# Altium schematic sheet SCH-CAPE-Nucleo_Interface_V1R0.SchDoc(SCH-CAPE-Nucleo_Interface_V1R0)
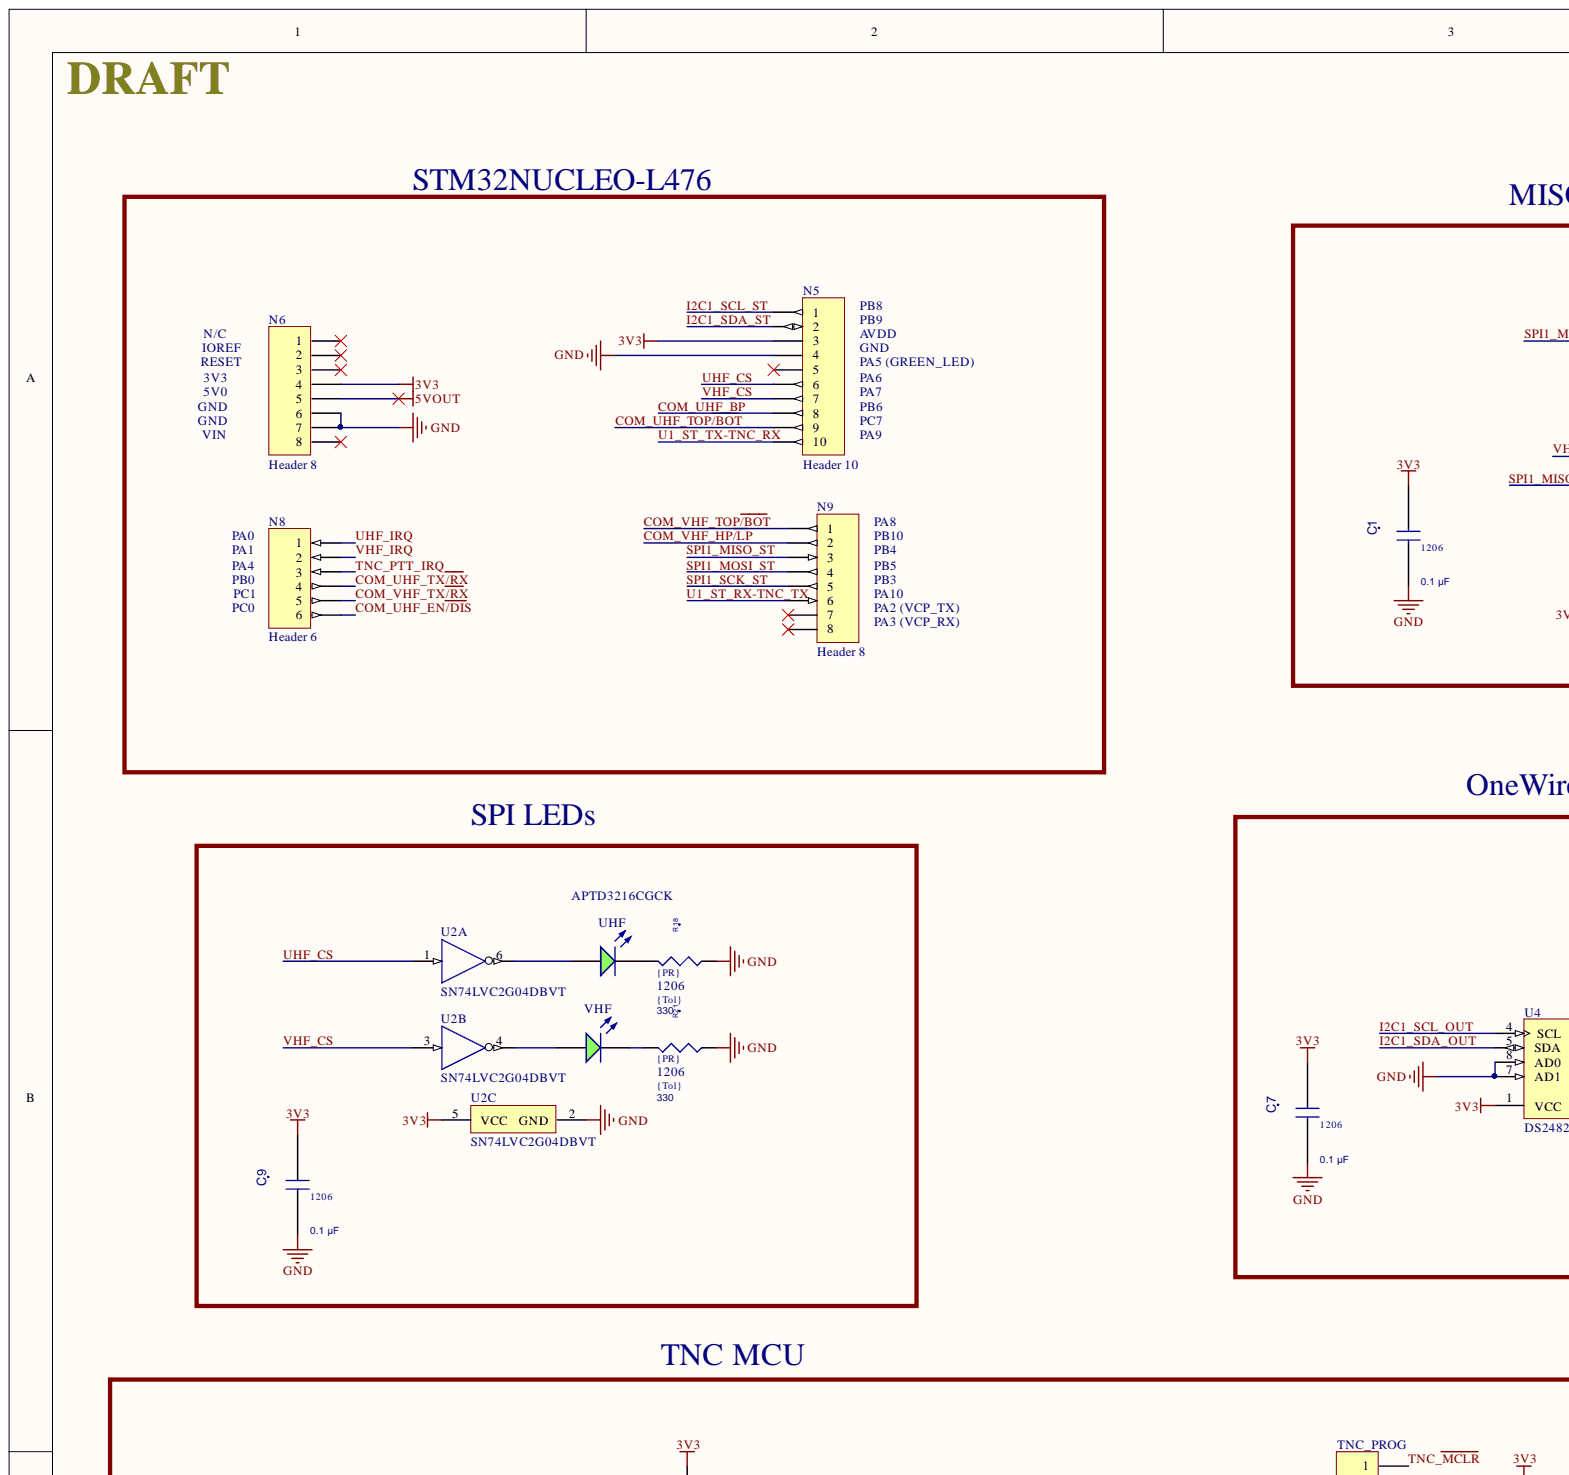
[diagram p0: SCH-CAPE-Nucleo_Interface_V1R0.SchDoc, top left]
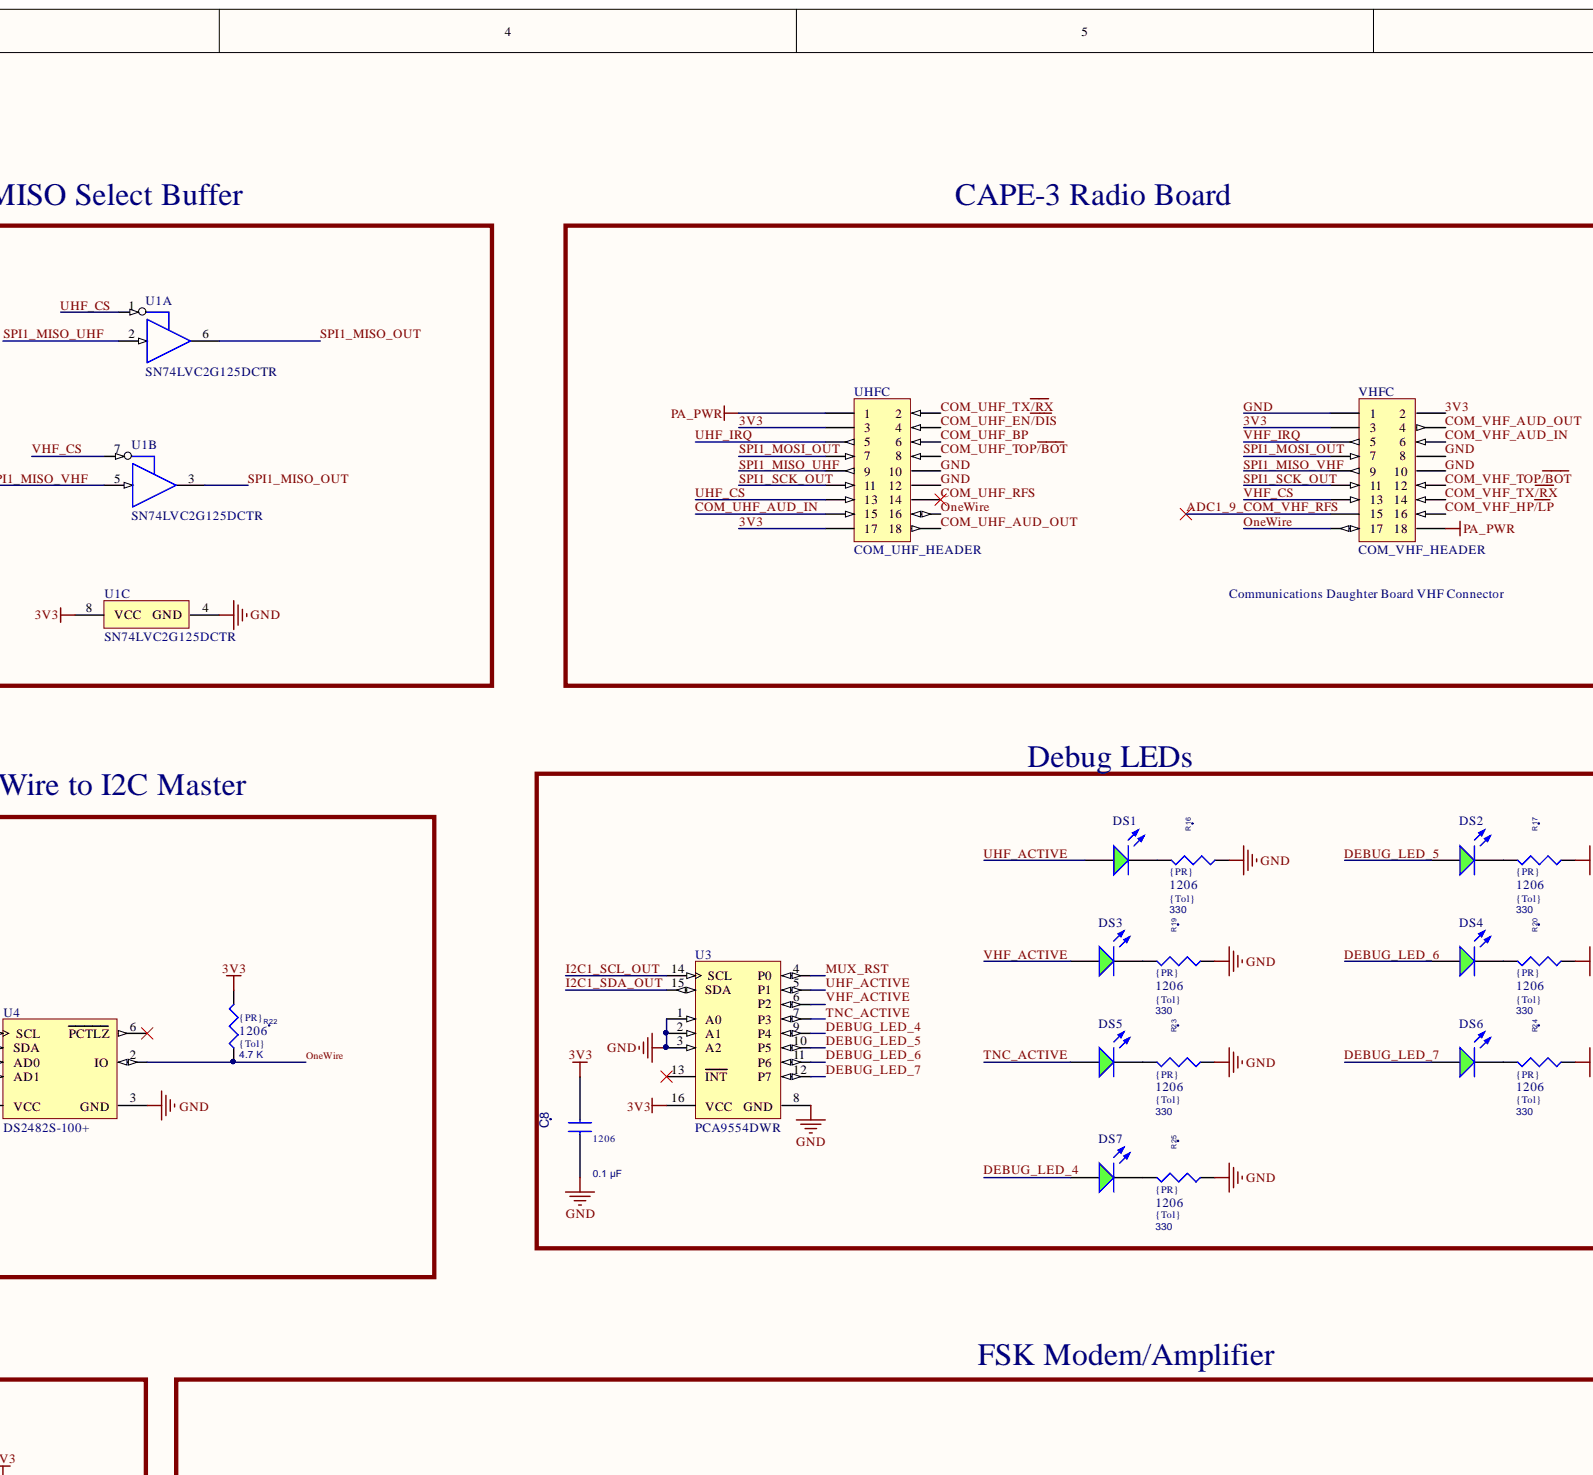
[diagram p1: SCH-CAPE-Nucleo_Interface_V1R0.SchDoc, top centre]
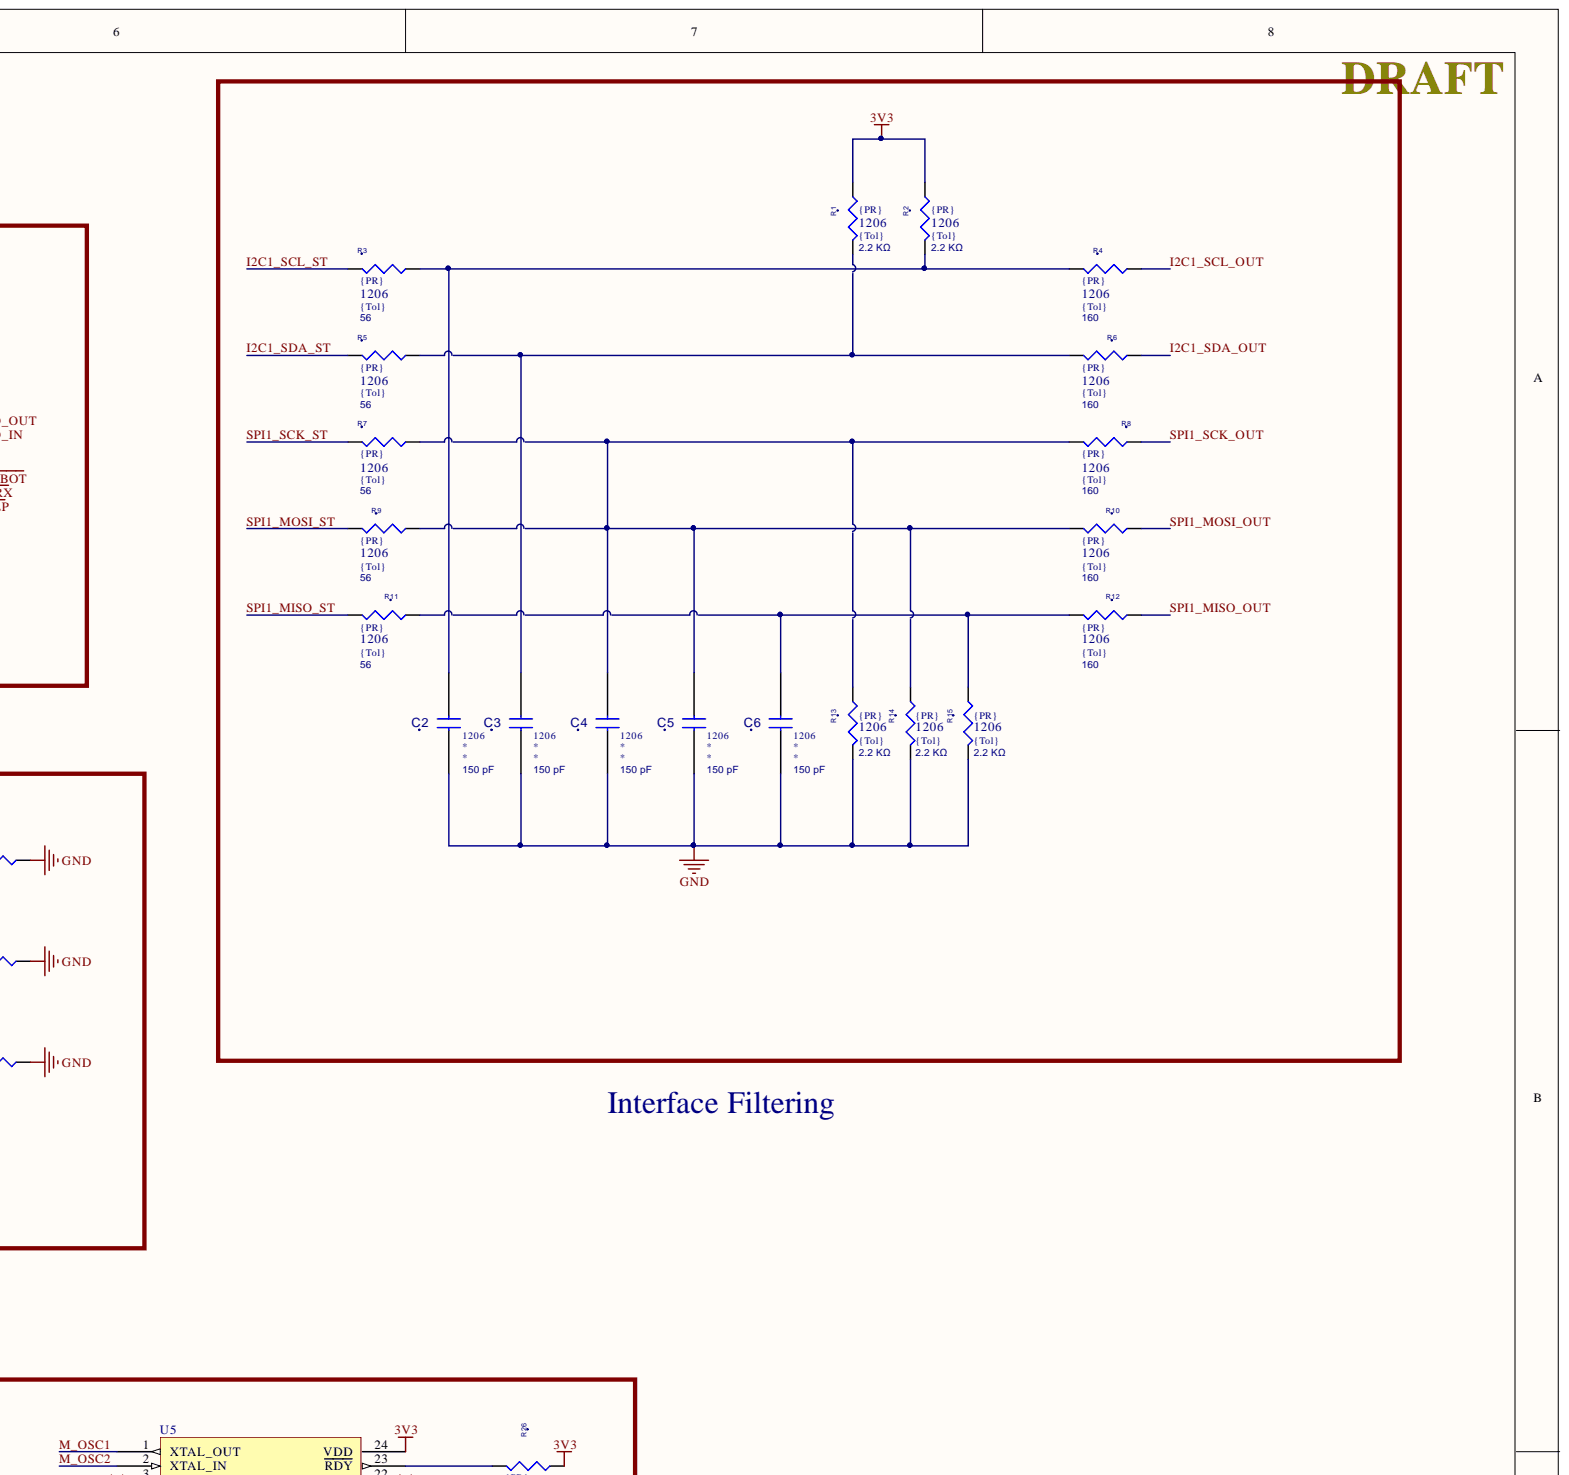
[diagram p2: SCH-CAPE-Nucleo_Interface_V1R0.SchDoc, top right]
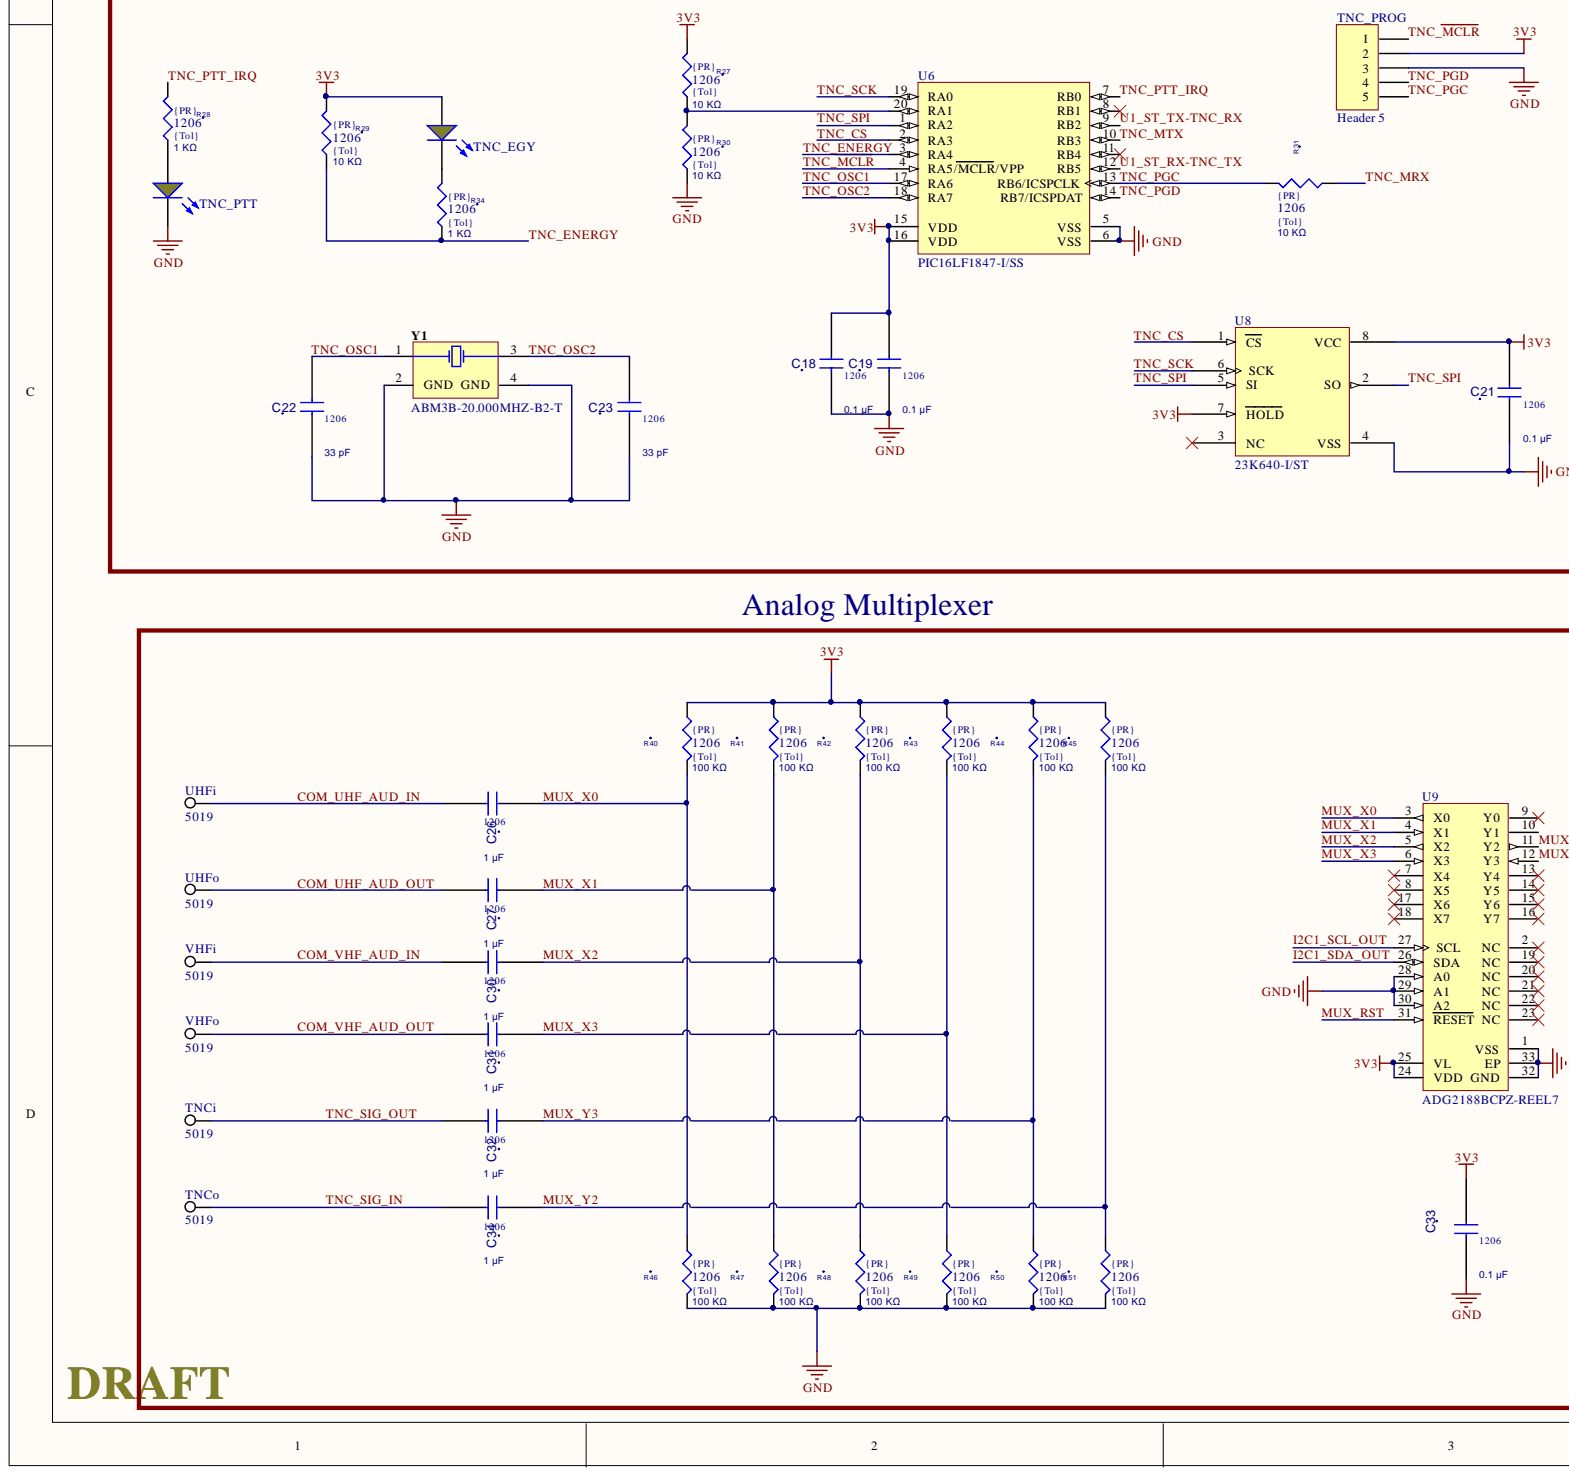
[diagram p3: SCH-CAPE-Nucleo_Interface_V1R0.SchDoc, bottom left]
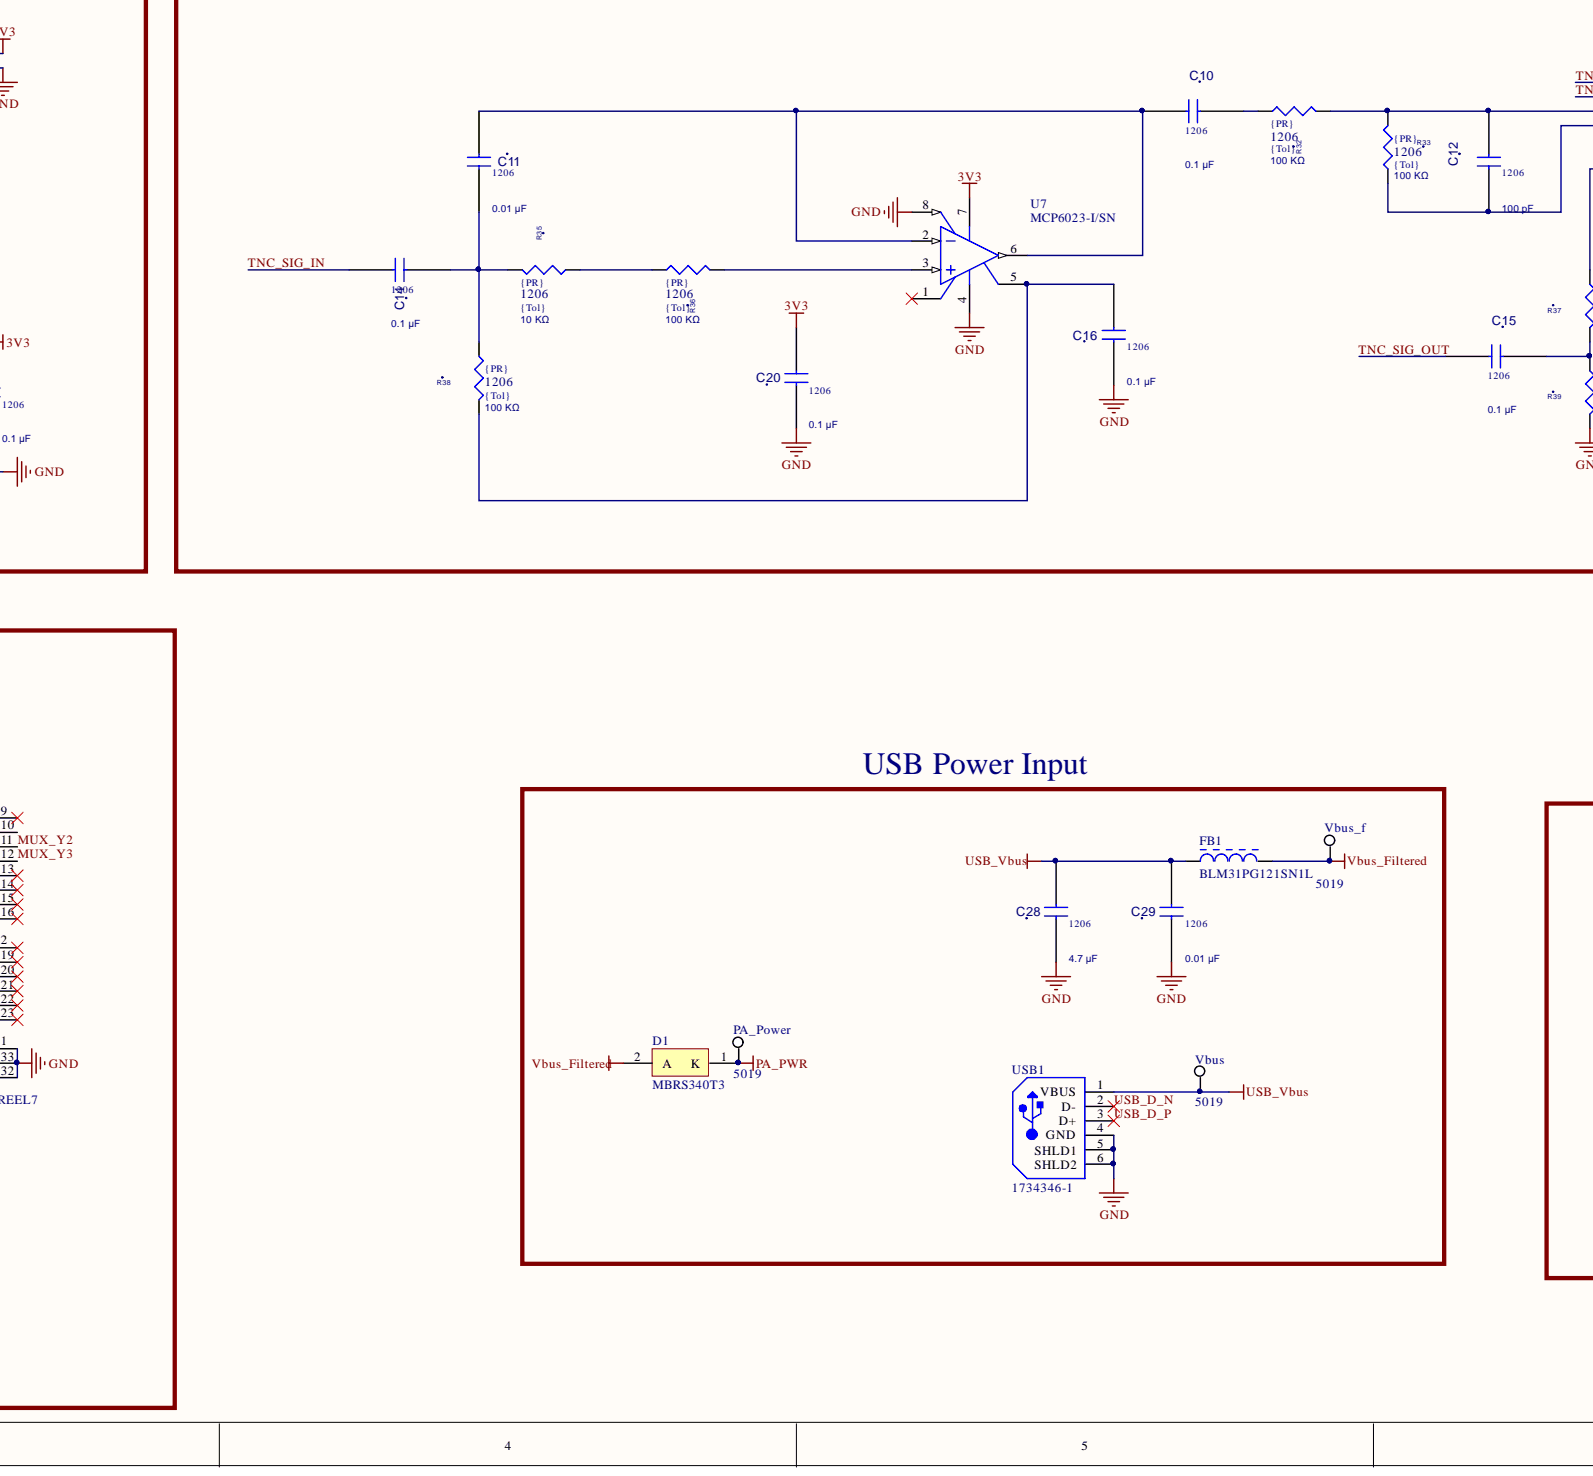
[diagram p4: SCH-CAPE-Nucleo_Interface_V1R0.SchDoc, bottom centre]
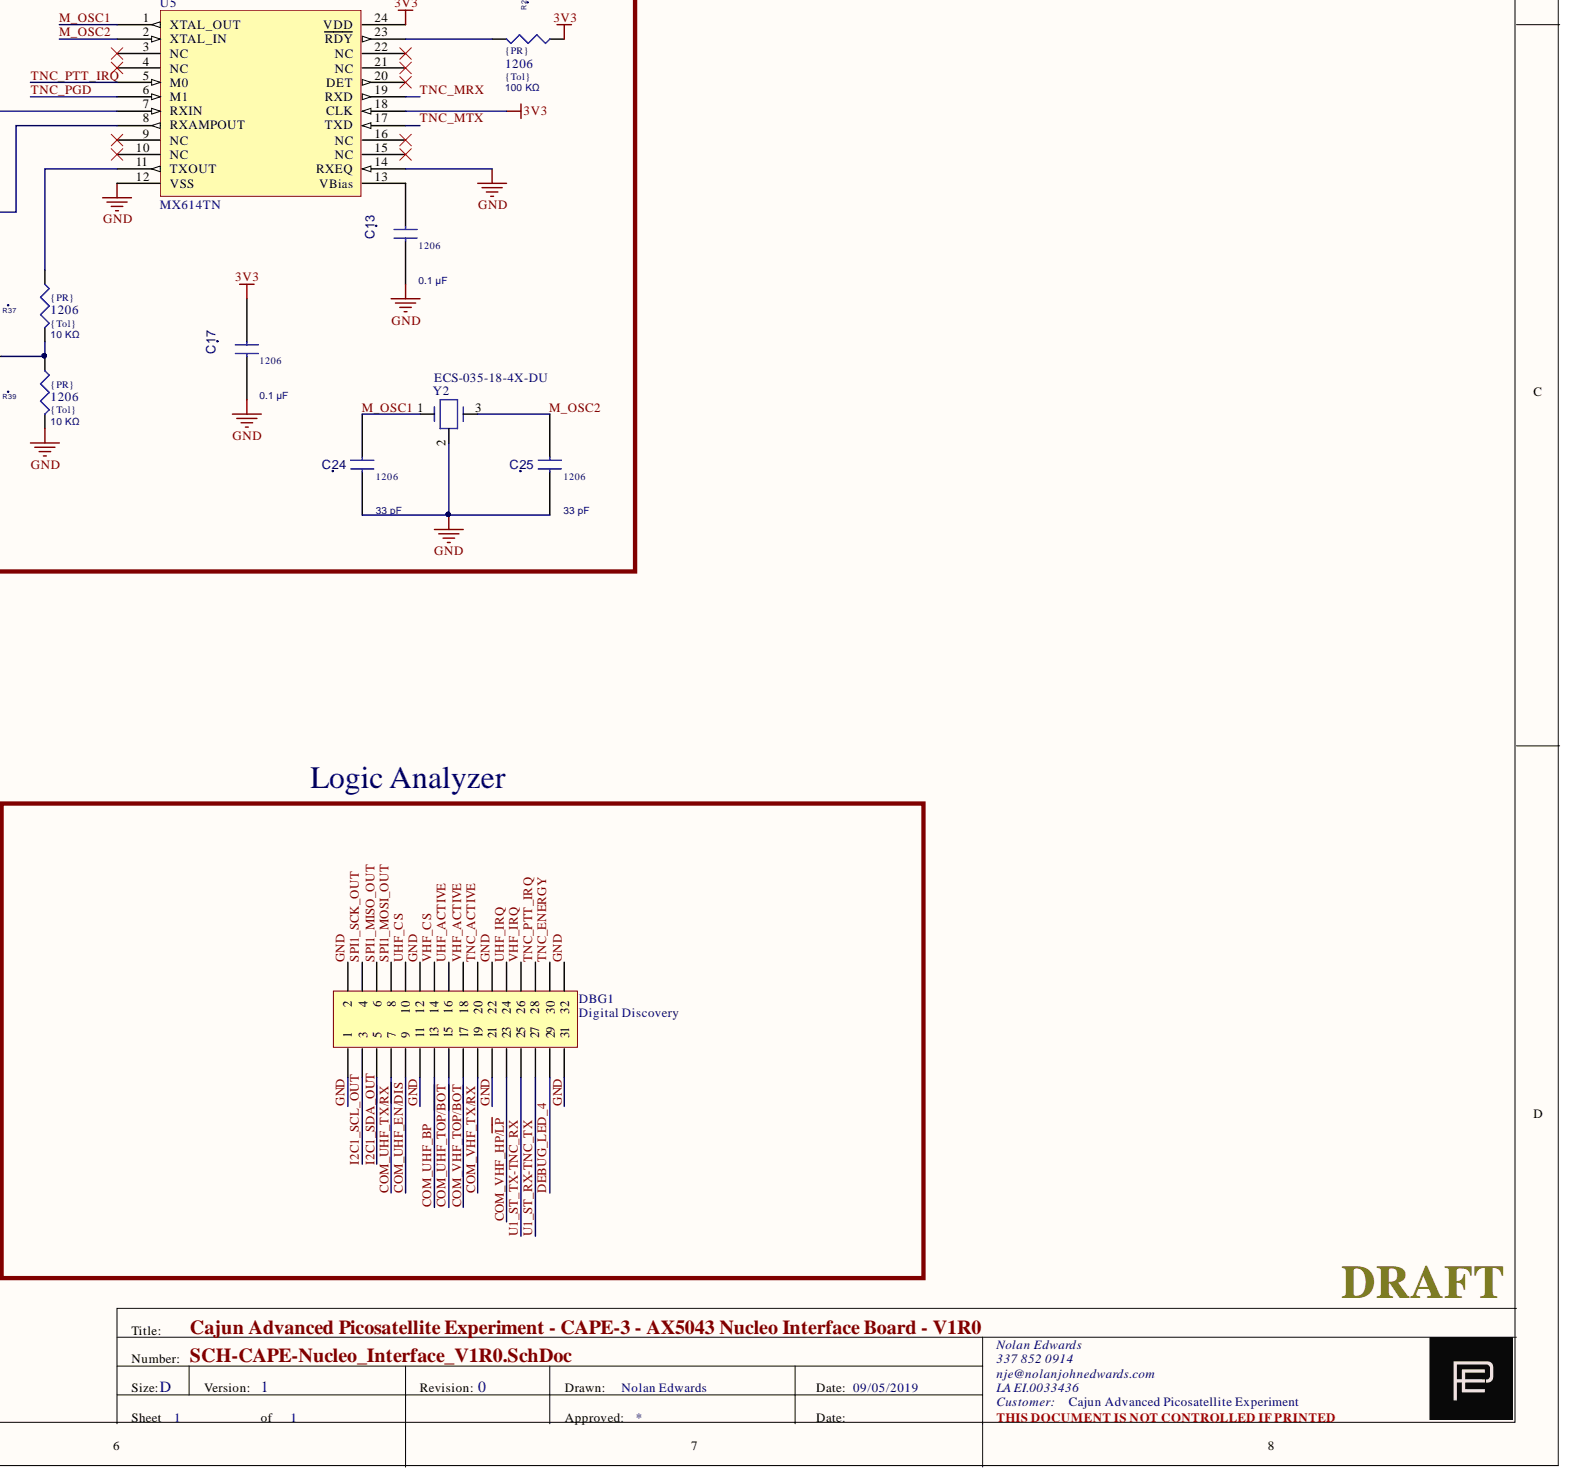
[diagram p5: SCH-CAPE-Nucleo_Interface_V1R0.SchDoc, bottom right]

## Parts
C1  value 0.1 µF  Package: 1206  [0805_GENERIC]  (hidden comment: SMT Capacitor)
C2  value 150 pF  Package: 1206  [1206_GENERIC]  (hidden comment: SMT Capacitor)
C3  value 150 pF  Package: 1206  [1206_GENERIC]  (hidden comment: SMT Capacitor)
C4  value 150 pF  Package: 1206  [1206_GENERIC]  (hidden comment: SMT Capacitor)
C5  value 150 pF  Package: 1206  [1206_GENERIC]  (hidden comment: SMT Capacitor)
C6  value 150 pF  Package: 1206  [1206_GENERIC]  (hidden comment: SMT Capacitor)
C7  value 0.1 µF  Package: 1206  [0805_GENERIC]  (hidden comment: SMT Capacitor)
C8  value 0.1 µF  Package: 1206  [0805_GENERIC]  (hidden comment: SMT Capacitor)
C9  value 0.1 µF  Package: 1206  [0805_GENERIC]  (hidden comment: SMT Capacitor)
C10  value 0.1 µF  Package: 1206  [0805_GENERIC]  (hidden comment: SMT Capacitor)
C11  value 0.01 µF  Package: 1206  [1206_GENERIC]  (hidden comment: SMT Capacitor)
C12  value 100 pF  Package: 1206  [0805_GENERIC]  (hidden comment: SMT Capacitor)
C13  value 0.1 µF  Package: 1206  [0805_GENERIC]  (hidden comment: SMT Capacitor)
C14  value 0.1 µF  Package: 1206  [0805_GENERIC]  (hidden comment: SMT Capacitor)
C15  value 0.1 µF  Package: 1206  [0805_GENERIC]  (hidden comment: SMT Capacitor)
C16  value 0.1 µF  Package: 1206  [0805_GENERIC]  (hidden comment: SMT Capacitor)
C17  value 0.1 µF  Package: 1206  [0805_GENERIC]  (hidden comment: SMT Capacitor)
C18  value 0.1 µF  Package: 1206  [0805_GENERIC]  (hidden comment: SMT Capacitor)
C19  value 0.1 µF  Package: 1206  [0805_GENERIC]  (hidden comment: SMT Capacitor)
C20  value 0.1 µF  Package: 1206  [0805_GENERIC]  (hidden comment: SMT Capacitor)
C21  value 0.1 µF  Package: 1206  [0805_GENERIC]  (hidden comment: SMT Capacitor)
C22  value 33 pF  Package: 1206  [0805_GENERIC]  (hidden comment: SMT Capacitor)
C23  value 33 pF  Package: 1206  [0805_GENERIC]  (hidden comment: SMT Capacitor)
C24  value 33 pF  Package: 1206  [0805_GENERIC]  (hidden comment: SMT Capacitor)
C25  value 33 pF  Package: 1206  [0805_GENERIC]  (hidden comment: SMT Capacitor)
C26  value 1 µF  Package: 1206  [0805_GENERIC]  (hidden comment: SMT Capacitor)
C27  value 1 µF  Package: 1206  [0805_GENERIC]  (hidden comment: SMT Capacitor)
C28  value 4.7 µF  Package: 1206  [1206_GENERIC]  (hidden comment: SMT Capacitor)
C29  value 0.01 µF  Package: 1206  [1206_GENERIC]  (hidden comment: SMT Capacitor)
C30  value 1 µF  Package: 1206  [0805_GENERIC]  (hidden comment: SMT Capacitor)
C31  value 1 µF  Package: 1206  [0805_GENERIC]  (hidden comment: SMT Capacitor)
C32  value 1 µF  Package: 1206  [0805_GENERIC]  (hidden comment: SMT Capacitor)
C33  value 0.1 µF  Package: 1206  [0805_GENERIC]  (hidden comment: SMT Capacitor)
C34  value 1 µF  Package: 1206  [0805_GENERIC]  (hidden comment: SMT Capacitor)
D1  MBRS340T3  [ONSC-SMC-2-403-03_V]
DBG1  Digital Discovery  [HDR2X16H]
DS1  APTD3216CGCK  [KING-APTD3216CGCK_V]
DS2  APTD3216CGCK  [KING-APTD3216CGCK_V]
DS3  APTD3216CGCK  [KING-APTD3216CGCK_V]
DS4  APTD3216CGCK  [KING-APTD3216CGCK_V]
DS5  APTD3216CGCK  [KING-APTD3216CGCK_V]
DS6  APTD3216CGCK  [KING-APTD3216CGCK_V]
DS7  APTD3216CGCK  [KING-APTD3216CGCK_V]
FB1  BLM31PG121SN1L  [MURA-BLM31-B35-CHIP-2_V2]
N5  Header 10  [HDR1X10]
N6  Header 8  [HDR1X8]
N8  Header 6  [HDR1X6]
N9  Header 8  [HDR1X8]
PA_Power  5019  [KSTN-5019_V]
R1  value 2.2 KΩ  Tolerance: {Tol}  Power Rating: {PR}  Package: 1206  [1206_GENERIC]  (hidden comment: SMT Resistor)
R2  value 2.2 KΩ  Tolerance: {Tol}  Power Rating: {PR}  Package: 1206  [1206_GENERIC]  (hidden comment: SMT Resistor)
R3  Tolerance: {Tol}  Power Rating: {PR}  Package: 1206  [1206_GENERIC]  (hidden comment: SMT Resistor)
R4  Tolerance: {Tol}  Power Rating: {PR}  Package: 1206  [1206_GENERIC]  (hidden comment: SMT Resistor)
R5  value 56  Tolerance: {Tol}  Power Rating: {PR}  Package: 1206  [1206_GENERIC]  (hidden comment: SMT Resistor)
R6  value 160  Tolerance: {Tol}  Power Rating: {PR}  Package: 1206  [1206_GENERIC]  (hidden comment: SMT Resistor)
R7  value 56  Tolerance: {Tol}  Power Rating: {PR}  Package: 1206  [1206_GENERIC]  (hidden comment: SMT Resistor)
R8  value 160  Tolerance: {Tol}  Power Rating: {PR}  Package: 1206  [1206_GENERIC]  (hidden comment: SMT Resistor)
R9  value 56  Tolerance: {Tol}  Power Rating: {PR}  Package: 1206  [1206_GENERIC]  (hidden comment: SMT Resistor)
R10  value 160  Tolerance: {Tol}  Power Rating: {PR}  Package: 1206  [1206_GENERIC]  (hidden comment: SMT Resistor)
R11  value 56  Tolerance: {Tol}  Power Rating: {PR}  Package: 1206  [1206_GENERIC]  (hidden comment: SMT Resistor)
R12  value 160  Tolerance: {Tol}  Power Rating: {PR}  Package: 1206  [1206_GENERIC]  (hidden comment: SMT Resistor)
R13  value 2.2 KΩ  Tolerance: {Tol}  Power Rating: {PR}  Package: 1206  [1206_GENERIC]  (hidden comment: SMT Resistor)
R14  value 2.2 KΩ  Tolerance: {Tol}  Power Rating: {PR}  Package: 1206  [1206_GENERIC]  (hidden comment: SMT Resistor)
R15  value 2.2 KΩ  Tolerance: {Tol}  Power Rating: {PR}  Package: 1206  [1206_GENERIC]  (hidden comment: SMT Resistor)
R16  Tolerance: {Tol}  Power Rating: {PR}  Package: 1206  [1206_GENERIC]  (hidden comment: SMT Resistor)
R17  Tolerance: {Tol}  Power Rating: {PR}  Package: 1206  [1206_GENERIC]  (hidden comment: SMT Resistor)
R18  Power Rating: {PR}  Package: 1206  [1206_GENERIC]  (hidden comment: SMT Resistor)
R19  value 330  Tolerance: {Tol}  Power Rating: {PR}  Package: 1206  [1206_GENERIC]  (hidden comment: SMT Resistor)
R20  value 330  Tolerance: {Tol}  Power Rating: {PR}  Package: 1206  [1206_GENERIC]  (hidden comment: SMT Resistor)
R21  value 330  Tolerance: {Tol}  Power Rating: {PR}  Package: 1206  [1206_GENERIC]  (hidden comment: SMT Resistor)
R22  value 4.7 K  Tolerance: {Tol}  Power Rating: {PR}  Package: 1206  [1206_GENERIC]  (hidden comment: SMT Resistor)
R23  value 330  Tolerance: {Tol}  Power Rating: {PR}  Package: 1206  [1206_GENERIC]  (hidden comment: SMT Resistor)
R24  value 330  Tolerance: {Tol}  Power Rating: {PR}  Package: 1206  [1206_GENERIC]  (hidden comment: SMT Resistor)
R25  value 330  Tolerance: {Tol}  Power Rating: {PR}  Package: 1206  [1206_GENERIC]  (hidden comment: SMT Resistor)
R26  value 100 KΩ  Tolerance: {Tol}  Power Rating: {PR}  Package: 1206  [1206_GENERIC]  (hidden comment: SMT Resistor)
R27  value 10 KΩ  Tolerance: {Tol}  Power Rating: {PR}  Package: 1206  [1206_GENERIC]  (hidden comment: SMT Resistor)
R28  value 1 KΩ  Tolerance: {Tol}  Power Rating: {PR}  Package: 1206  [1206_GENERIC]  (hidden comment: SMT Resistor)
R29  value 10 KΩ  Tolerance: {Tol}  Power Rating: {PR}  Package: 1206  [1206_GENERIC]  (hidden comment: SMT Resistor)
R30  value 10 KΩ  Tolerance: {Tol}  Power Rating: {PR}  Package: 1206  [1206_GENERIC]  (hidden comment: SMT Resistor)
R31  value 10 KΩ  Tolerance: {Tol}  Power Rating: {PR}  Package: 1206  [1206_GENERIC]  (hidden comment: SMT Resistor)
R32  value 100 KΩ  Tolerance: {Tol}  Power Rating: {PR}  Package: 1206  [1206_GENERIC]  (hidden comment: SMT Resistor)
R33  value 100 KΩ  Tolerance: {Tol}  Power Rating: {PR}  Package: 1206  [1206_GENERIC]  (hidden comment: SMT Resistor)
R34  value 1 KΩ  Tolerance: {Tol}  Power Rating: {PR}  Package: 1206  [1206_GENERIC]  (hidden comment: SMT Resistor)
R35  value 10 KΩ  Tolerance: {Tol}  Power Rating: {PR}  Package: 1206  [1206_GENERIC]  (hidden comment: SMT Resistor)
R36  value 100 KΩ  Tolerance: {Tol}  Power Rating: {PR}  Package: 1206  [1206_GENERIC]  (hidden comment: SMT Resistor)
R37  value 10 KΩ  Tolerance: {Tol}  Power Rating: {PR}  Package: 1206  [1206_GENERIC]  (hidden comment: SMT Resistor)
R38  value 100 KΩ  Tolerance: {Tol}  Power Rating: {PR}  Package: 1206  [1206_GENERIC]  (hidden comment: SMT Resistor)
R39  value 10 KΩ  Tolerance: {Tol}  Power Rating: {PR}  Package: 1206  [1206_GENERIC]  (hidden comment: SMT Resistor)
R40  value 100 KΩ  Tolerance: {Tol}  Power Rating: {PR}  Package: 1206  [1206_GENERIC]  (hidden comment: SMT Resistor)
R41  value 100 KΩ  Tolerance: {Tol}  Power Rating: {PR}  Package: 1206  [1206_GENERIC]  (hidden comment: SMT Resistor)
R42  value 100 KΩ  Tolerance: {Tol}  Power Rating: {PR}  Package: 1206  [1206_GENERIC]  (hidden comment: SMT Resistor)
R43  value 100 KΩ  Tolerance: {Tol}  Power Rating: {PR}  Package: 1206  [1206_GENERIC]  (hidden comment: SMT Resistor)
R44  value 100 KΩ  Tolerance: {Tol}  Power Rating: {PR}  Package: 1206  [1206_GENERIC]  (hidden comment: SMT Resistor)
R45  value 100 KΩ  Tolerance: {Tol}  Power Rating: {PR}  Package: 1206  [1206_GENERIC]  (hidden comment: SMT Resistor)
R46  value 100 KΩ  Tolerance: {Tol}  Power Rating: {PR}  Package: 1206  [1206_GENERIC]  (hidden comment: SMT Resistor)
R47  value 100 KΩ  Tolerance: {Tol}  Power Rating: {PR}  Package: 1206  [1206_GENERIC]  (hidden comment: SMT Resistor)
R48  value 100 KΩ  Tolerance: {Tol}  Power Rating: {PR}  Package: 1206  [1206_GENERIC]  (hidden comment: SMT Resistor)
R49  value 100 KΩ  Tolerance: {Tol}  Power Rating: {PR}  Package: 1206  [1206_GENERIC]  (hidden comment: SMT Resistor)
R50  value 100 KΩ  Tolerance: {Tol}  Power Rating: {PR}  Package: 1206  [1206_GENERIC]  (hidden comment: SMT Resistor)
R51  value 100 KΩ  Tolerance: {Tol}  Power Rating: {PR}  Package: 1206  [1206_GENERIC]  (hidden comment: SMT Resistor)
TNC_EGY  APTD3216CGCK  [KING-APTD3216CGCK_V]
TNC_PROG  Header 5  [HDR1X5]
TNC_PTT  APTD3216CGCK  [KING-APTD3216CGCK_V]
TNCi  5019  [KSTN-5019_V]
TNCo  5019  [KSTN-5019_V]
U1  SN74LVC2G125DCTR  [DCT0008A_M]  (parts A,B,C)
U2  SN74LVC2G04DBVT  [DBV0006A_M]  (parts A,B,C)
U3  PCA9554DWR  [DW0016A_M]
U4  DS2482S-100+  [MAXM-SO-8_V]
U5  MX614TN  [24SSOP209_M]
U6  PIC16LF1847-I/SS  [MCHP-SSOP-SS20_M]
U7  MCP6023-I/SN  [SOIC-SN8_L]
U8  23K640-I/ST  [TSSOP-ST8_M]
U9  ADG2188BCPZ-REEL7  [ADI-CP-32-2_M]
UHF  APTD3216CGCK  [KING-APTD3216CGCK_V]
UHFC  COM_UHF_HEADER  [Molex 87758-1816]
UHFi  5019  [KSTN-5019_V]
UHFo  5019  [KSTN-5019_V]
USB1  1734346-1  [TECO-1734346-1_V]
Vbus  5019  [KSTN-5019_V]
Vbus_f  5019  [KSTN-5019_V]
VHF  APTD3216CGCK  [KING-APTD3216CGCK_V]
VHFC  COM_VHF_HEADER  [Molex 87758-1816]
VHFi  5019  [KSTN-5019_V]
VHFo  5019  [KSTN-5019_V]
Y1  ABM3B-20.000MHZ-B2-T  [ABRA-ABM3B_V]
Y2  ECS-035-18-4X-DU  [ECS-4X-3IL]

## Nets
5VOUT: N6.5
PA_PWR: D1.1 PA_Power.1 UHFC.1 VHFC.18
USB_Vbus: C28.2 C29.2 FB1.1 USB1.1(VBUS) Vbus.1
Vbus_Filtered: D1.2 FB1.2 Vbus_f.1
3V3: C1.1 C7.1 C8.1 C9.1 C17.1 C18.2 C19.2 C20.2 C21.2 C33.1 N5.3 N6.4 R1.2 R2.2 R22.1 R26.2 R27.1 R29.1 R40.2 R41.2 R42.2 R43.2 R44.2 R45.2 TNC_EGY.1 TNC_PROG.2 U1.8(VCC) U2.5(VCC) U3.16(VCC) U4.1(VCC) U5.18(CLK) U5.24(VDD) U6.15(VDD) U6.16(VDD) U7.7 U8.7(HOLD) U8.8(VCC) U9.24(VDD) U9.25(VL) UHFC.3 UHFC.17 VHFC.2 VHFC.3
ADC1_9_COM_VHF_RFS: VHFC.15
COM_UHF_AUD_IN: C26.2 UHFC.15 UHFi.1
COM_UHF_AUD_OUT: C27.2 UHFC.18 UHFo.1
COM_UHF_BP: DBG1.13 N5.8 UHFC.6
COM_UHF_EN/~{DIS}: DBG1.9 N8.6 UHFC.4
COM_UHF_RFS: UHFC.14
COM_UHF_TOP/~{BOT}: DBG1.15 N5.9 UHFC.8
COM_UHF_TX/~{RX}: DBG1.7 N8.4 UHFC.2
COM_VHF_AUD_IN: C30.2 VHFC.6 VHFi.1
COM_VHF_AUD_OUT: C31.2 VHFC.4 VHFo.1
COM_VHF_HP/~{LP}: DBG1.23 N9.2 VHFC.16
COM_VHF_TOP/~{BOT}: DBG1.17 N9.1 VHFC.12
COM_VHF_TX/~{RX}: DBG1.19 N8.5 VHFC.14
DEBUG_LED_4: DBG1.29 DS7.1 U3.9(P4)
DEBUG_LED_5: DS2.1 U3.10(P5)
DEBUG_LED_6: DS4.1 U3.11(P6)
DEBUG_LED_7: DS6.1 U3.12(P7)
GND: C1.2 C2.1 C3.1 C4.1 C5.1 C6.1 C7.2 C8.2 C9.2 C13.2 C16.1 C17.2 C18.1 C19.1 C20.1 C21.1 C22.1 C23.1 C24.1 C25.1 C28.1 C29.1 C33.2 DBG1.1 DBG1.2 DBG1.11 DBG1.12 DBG1.21 DBG1.22 DBG1.31 DBG1.32 N5.4 N6.6 N6.7 R13.1 R14.1 R15.1 R16.2 R17.2 R18.2 R19.2 R20.2 R21.2 R23.2 R24.2 R25.2 R30.2 R39.1 R46.1 R47.1 R48.1 R49.1 R50.1 R51.1 TNC_PROG.3 TNC_PTT.2 U1.4(GND) U2.2(GND) U3.1(A0) U3.2(A1) U3.3(A2) U3.8(GND) U4.3(GND) U4.7(AD1) U4.8(AD0) U5.12(VSS) U5.14(RXEQ) U6.5(VSS) U6.6(VSS) U7.4 U7.8 U8.4(VSS) U9.1(VSS) U9.28(A0) U9.29(A1) U9.30(A2) U9.32(GND) U9.33(EP) UHFC.10 UHFC.12 USB1.4(GND) USB1.5(SHLD1) USB1.6(SHLD2) VHFC.1 VHFC.8 VHFC.10 Y1.2(GND) Y1.4(GND) Y2.2
I2C1_SCL_OUT: DBG1.3 R4.2 U3.14(SCL) U4.4(SCL) U9.27(SCL)
I2C1_SCL_ST: N5.1 R3.1
I2C1_SDA_OUT: DBG1.5 R6.2 U3.15(SDA) U4.5(SDA) U9.26(SDA)
I2C1_SDA_ST: N5.2 R5.1
M_OSC1: C24.2 U5.1(XTAL_OUT) Y2.1
M_OSC2: C25.2 U5.2(XTAL_IN) Y2.3
MUX_RST: U3.4(P0) U9.31(RESET)
MUX_X0: C26.1 R40.1 R46.2 U9.3(X0)
MUX_X1: C27.1 R41.1 R47.2 U9.4(X1)
MUX_X2: C30.1 R42.1 R48.2 U9.5(X2)
MUX_X3: C31.1 R43.1 R49.2 U9.6(X3)
MUX_Y2: C34.1 R45.1 R51.2 U9.11(Y2)
MUX_Y3: C32.1 R44.1 R50.2 U9.12(Y3)
OneWire: R22.2 U4.2(IO) UHFC.16 VHFC.17
SPI1_MISO_OUT: DBG1.6 R12.2 U1.3 U1.6
SPI1_MISO_ST: N9.3 R11.1
SPI1_MISO_UHF: U1.2 UHFC.9
SPI1_MISO_VHF: U1.5 VHFC.9
SPI1_MOSI_OUT: DBG1.8 R10.2 UHFC.7 VHFC.7
SPI1_MOSI_ST: N9.4 R9.1
SPI1_SCK_OUT: DBG1.4 R8.2 UHFC.11 VHFC.11
SPI1_SCK_ST: N9.5 R7.1
TNC_ACTIVE: DBG1.20 DS5.1 U3.7(P3)
TNC_CS: U6.2(RA3) U8.1(CS)
TNC_ENERGY: DBG1.30 R29.2 R34.2 U6.3(RA4)
TNC_~{MCLR}: TNC_PROG.1 U6.4(RA5/MCLR/VPP)
TNC_MRX: R31.2 U5.19(RXD)
TNC_MTX: U5.17(TXD) U6.10(RB3)
TNC_OSC1: C22.2 U6.17(RA6) Y1.1
TNC_OSC2: C23.2 U6.18(RA7) Y1.3
TNC_PGC: R31.1 TNC_PROG.5 U6.13(RB6/ICSPCLK)
TNC_PGD: TNC_PROG.4 U5.6(M1) U6.14(RB7/ICSPDAT)
TNC_PTT_IRQ: DBG1.28 N8.3 R28.1 U5.5(M0) U6.7(RB0)
TNC_SCK: U6.19(RA0) U8.6(SCK)
TNC_SIG_IN: C14.2 C34.2 TNCo.1
TNC_SIG_OUT: C15.2 C32.2 TNCi.1
TNC_SPI: U6.1(RA2) U8.2(SO) U8.5(SI)
U1_ST_RX-TNC_TX: DBG1.27 N9.6 U6.12(RB5)
U1_ST_TX-TNC_RX: DBG1.25 N5.10 U6.9(RB2)
UHF_ACTIVE: DBG1.16 DS1.1 U3.5(P1)
UHF_CS: DBG1.10 N5.6 U1.1 U2.1 UHFC.13
UHF_IRQ: DBG1.24 N8.1 UHFC.5
USB_D_N: USB1.2(D-)
USB_D_P: USB1.3(D+)
VHF_ACTIVE: DBG1.18 DS3.1 U3.6(P2)
VHF_CS: DBG1.14 N5.7 U1.7 U2.3 VHFC.13
VHF_IRQ: DBG1.26 N8.2 VHFC.5
NetC2_2: C2.2 R2.1 R3.2 R4.1
NetC3_2: C3.2 R1.1 R5.2 R6.1
NetC4_2: C4.2 C5.2 R7.2 R8.1 R9.2 R10.1 R13.2 R14.2
NetC6_2: C6.2 R11.2 R12.1 R15.2
NetC10_1: C10.1 R32.2
NetC10_2: C10.2 C11.1 U7.2 U7.6
NetC11_2: C11.2 C14.1 R35.1 R38.2
NetC12_1: C12.1 R32.1 R33.1 U5.7(RXIN)
NetC12_2: C12.2 R33.2 U5.8(RXAMPOUT)
NetC13_1: C13.1 U5.13(VBias)
NetC15_1: C15.1 R37.1 R39.2
NetC16_2: C16.2 R38.1 U7.5
NetDS1_2: DS1.2 R16.1
NetDS2_2: DS2.2 R17.1
NetDS3_2: DS3.2 R19.1
NetDS4_2: DS4.2 R20.1
NetDS5_2: DS5.2 R23.1
NetDS6_2: DS6.2 R24.1
NetDS7_2: DS7.2 R25.1
NetR18_1: R18.1 UHF.2
NetR21_1: R21.1 VHF.2
NetR26_1: R26.1 U5.23(RDY)
NetR27_2: R27.2 R30.1 U6.20(RA1)
NetR28_2: R28.2 TNC_PTT.1
NetR34_1: R34.1 TNC_EGY.2
NetR35_2: R35.2 R36.2
NetR36_1: R36.1 U7.3
NetR37_2: R37.2 U5.11(TXOUT)
NetU2_4: U2.4 VHF.1
NetU2_6: U2.6 UHF.1

Not connected: N5 5; N6 1 2 3 8; N9 7 8; U3 13(INT); U4 6(PCTLZ); U5 3(NC) 4(NC) 9(NC) 10(NC) 15(NC) 16(NC) 20(DET) 21(NC) 22(NC); U6 8(RB1) 11(RB4); U7 1; U8 3(NC); U9 2(NC) 7(X4) 8(X5) 9(Y0) 10(Y1) 13(Y4) 14(Y5) 15(Y6) 16(Y7) 17(X6) 18(X7) 19(NC) 20(NC) 21(NC) 22(NC) 23(NC)
